# Altium schematic sheet Debug Schematic Prints("All Documents",Physical)
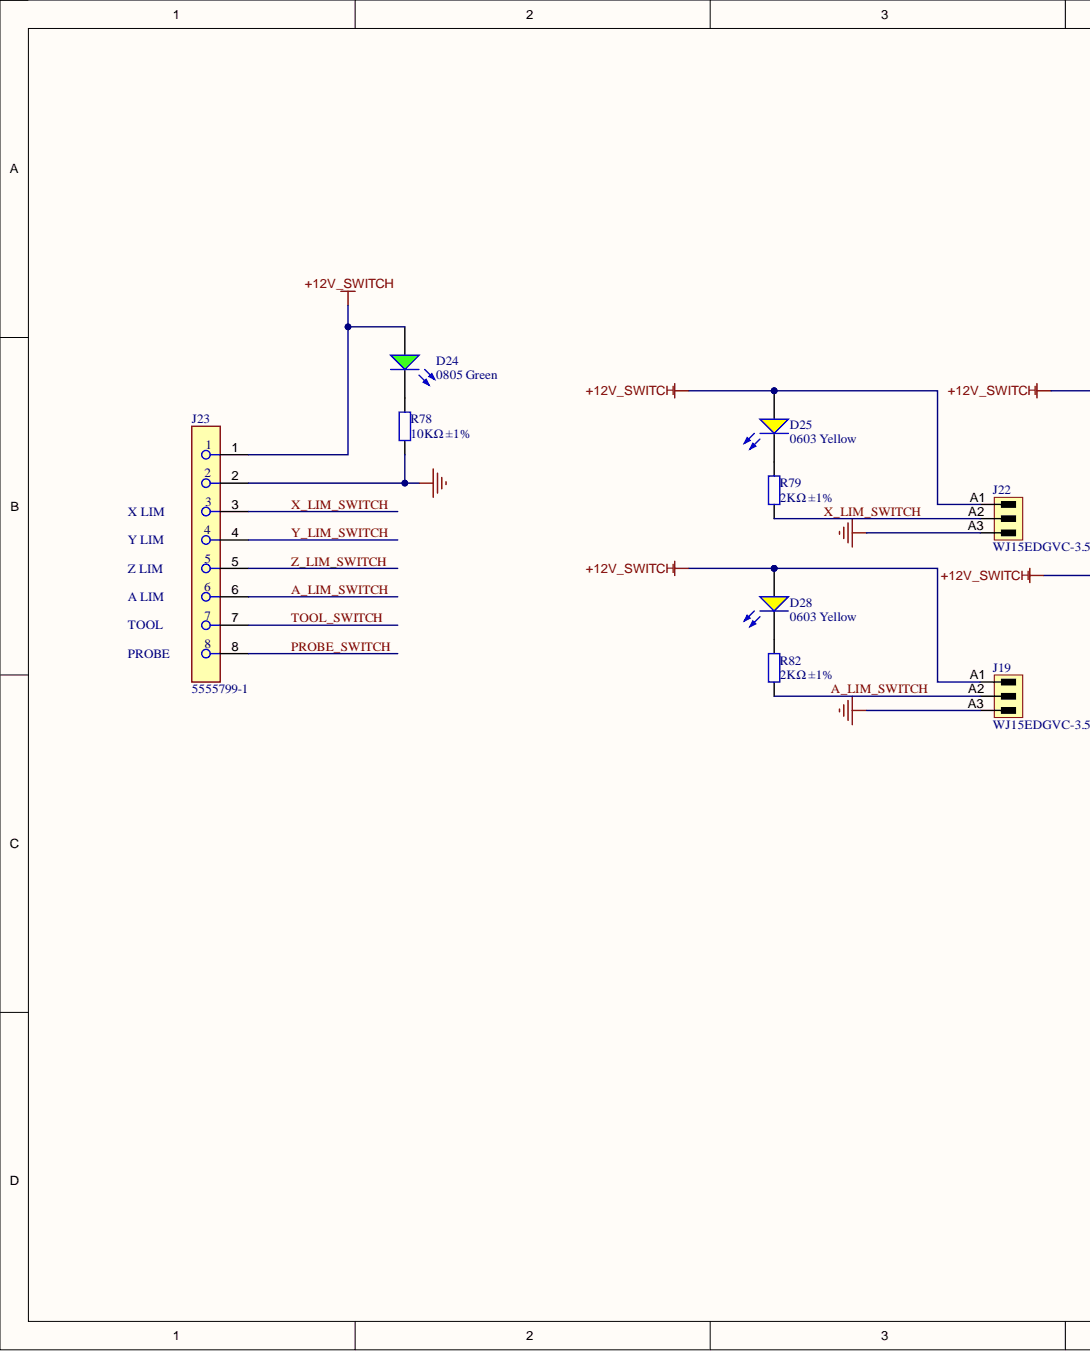
[diagram p0: Debug Schematic Prints("All Documents",Physical), left]
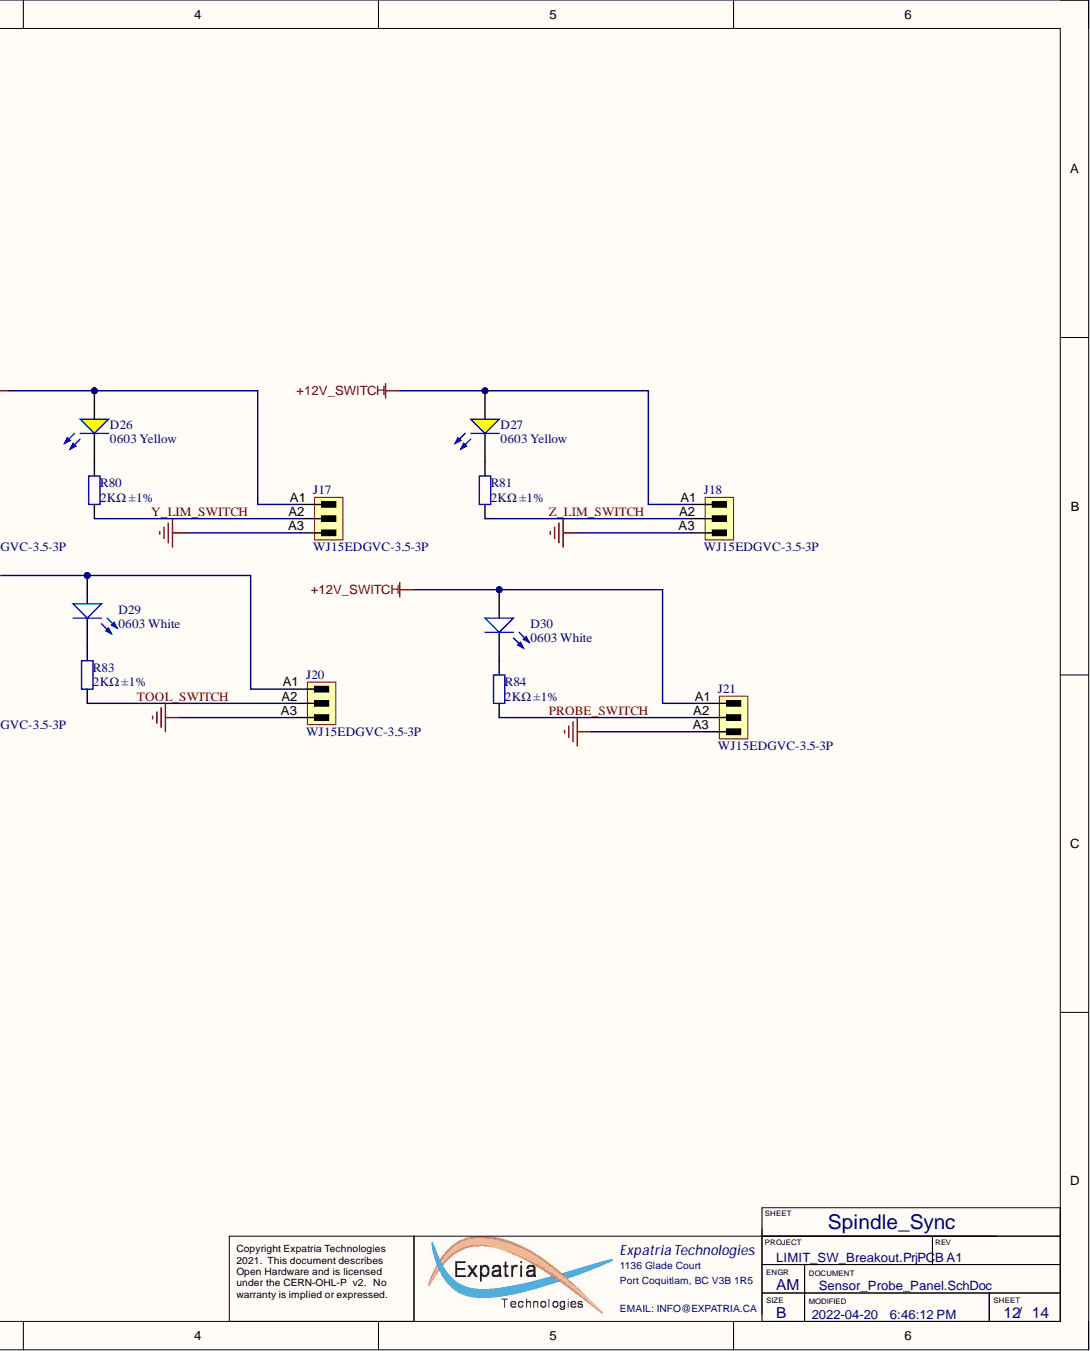
[diagram p1: Debug Schematic Prints("All Documents",Physical), right]

## Parts
D24  0805 Green  Color: Green  Package: 0805  [0805_LED_S1]
D25  0603 Yellow  Color: Yellow  Package: 0603  [0603_LED_S1]
D26  0603 Yellow  Color: Yellow  Package: 0603  [0603_LED_S1]
D27  0603 Yellow  Color: Yellow  Package: 0603  [0603_LED_S1]
D28  0603 Yellow  Color: Yellow  Package: 0603  [0603_LED_S1]
D29  0603 White  Color: White  Package: 0603  [0603_LED_S1]
D30  0603 White  Color: White  Package: 0603  [0603_LED_S1]
J17  WJ15EDGVC-3.5-3P  [SL_3_50_180G_03]
J18  WJ15EDGVC-3.5-3P  [SL_3_50_180G_03]
J19  WJ15EDGVC-3.5-3P  [SL_3_50_180G_03]
J20  WJ15EDGVC-3.5-3P  [SL_3_50_180G_03]
J21  WJ15EDGVC-3.5-3P  [SL_3_50_180G_03]
J22  WJ15EDGVC-3.5-3P  [SL_3_50_180G_03]
J23  5555799-1  [FP-5555799-1-MFG]
R78  10KΩ ±1%  Resistance: 10KΩ  [0603_R]
R79  2KΩ ±1%  Resistance: 2KΩ  [0603_R]
R80  2KΩ ±1%  Resistance: 2KΩ  [0603_R]
R81  2KΩ ±1%  Resistance: 2KΩ  [0603_R]
R82  2KΩ ±1%  Resistance: 2KΩ  [0603_R]
R83  2KΩ ±1%  Resistance: 2KΩ  [0603_R]
R84  2KΩ ±1%  Resistance: 2KΩ  [0603_R]

## Nets
+12V_SWITCH: D24.A D25.A D26.A D27.A D28.A D29.A D30.A J17.A1 J18.A1 J19.A1 J20.A1 J21.A1 J22.A1 J23.1
GND_SWITCH: J17.A3 J18.A3 J19.A3 J20.A3 J21.A3 J22.A3 J23.2 R78.1
A_LIM_SWITCH: J19.A2 J23.6 R82.2
PROBE_SWITCH: J21.A2 J23.8 R84.2
TOOL_SWITCH: J20.A2 J23.7 R83.2
X_LIM_SWITCH: J22.A2 J23.3 R79.2
Y_LIM_SWITCH: J17.A2 J23.4 R80.2
Z_LIM_SWITCH: J18.A2 J23.5 R81.2
NetD24_K: D24.K R78.2
NetD25_K: D25.K R79.1
NetD26_K: D26.K R80.1
NetD27_K: D27.K R81.1
NetD28_K: D28.K R82.1
NetD29_K: D29.K R83.1
NetD30_K: D30.K R84.1

## Library data of the parts
D24 = 0805 LED Green: LED; Component Kind: Standard; Library Name: LED.SchLib; Supplier: LC; SuppliersPartNumber: C2297
D25-D28 = 0603 LED Yellow: LED; Component Kind: Standard; Library Name: LED.SchLib; Supplier: LC; SuppliersPartNumber: C72038
D29 D30 = 0603 LED White: LED; Component Kind: Standard; Library Name: LED.SchLib; Supplier: LC; SuppliersPartNumber: C2290
J17-J22 = CMP-1559-00904-1: Header,Male Pin,Covered(4 Sided) 3 1 0.138"（3.50mm） 3 P=3.5mm Pluggable System Terminal Block RoHS; Manufacturer: Ningbo Kangnex Elec; Pitch_inch: 0.138 inch; Pitch_mm: 3.5 mm; Short_text_for_material: SL 3.50/03/180G 3.2SN OR BX; Side_termination_characteristic: closed side; Solder_pin_length_mm: 3.2 mm; UL_94_flammability_rating: V-0; Component Kind: Standard; PackageReference: SL_3_50_180G_03; Packaging: Box; PartNumber: WJ15EDGVC-3.5-3P; Revision: V1; SuppliersPartNumber: C192778; Library Name: PrintNC_GRBLHAL_Breakout.SCHLIB
J23 = CMP-03371-000003-1: CONN MOD JACK 8P8C VERT UNSHLD; Manufacturer: TE Connectivity; Is Female: yes; Number Of Contacts: 8; Pitch: 2.54mm; Component Kind: Standard; Device Class L1: Connectors; Device Class L2: Modular / Ethernet Connectors; Device Class L3: unset; Digikey Description: CONN MOD JACK 8P8C VERT UNSHLD; Manufacturer Part Number: 5555799-1; Mouser Description: Conn RJ-45 F 8 POS 2.54mm Solder ST Thru-Hole 8 Terminal 1 Port Cat 3 Box/Package/Tray; Octopart: https://octopart.com/5555799-1-te+connectivity-39526335; Package: 5555799-1; SuppliersPartNumber: C305983; Temperature: -40°C to +85°C; Temperature Range High: +85°C; Temperature Range Low: -40°C; Library Name: PrintNC_GRBLHAL_Breakout.SCHLIB
R78 = 0603 10K (1002) 1%: Chip resistor; Manufacturer: Uniroyal Elec; PartNumber: 0603WAF1002T5E; Power: 1/10W; Component Kind: Standard; ComponentLink1Description: Supplier link; ComponentLink1URL: http://www.szlcsc.com/product/details_26547.html; Package: 0603; Supplier: LC; SuppliersPartNumber: C25804; Tolerance: 1%; Library Name: PrintNC_GRBLHAL_Breakout.SCHLIB
R79-R84 = 0603 2K (2001) 1%: Chip resistor; Manufacturer: Uniroyal Elec; PartNumber: 0603WAF2001T5E; Power: 1/10W; Component Kind: Standard; ComponentLink1Description: Supplier link; ComponentLink1URL: http://www.szlcsc.com/product/details_23702.html; Package: 0603; Supplier: LC; SuppliersPartNumber: C22975; Tolerance: 1%; Library Name: PrintNC_GRBLHAL_Breakout.SCHLIB
